# Altium schematic sheet 02 POE Main Power.SchDoc("02 POE Main Power")
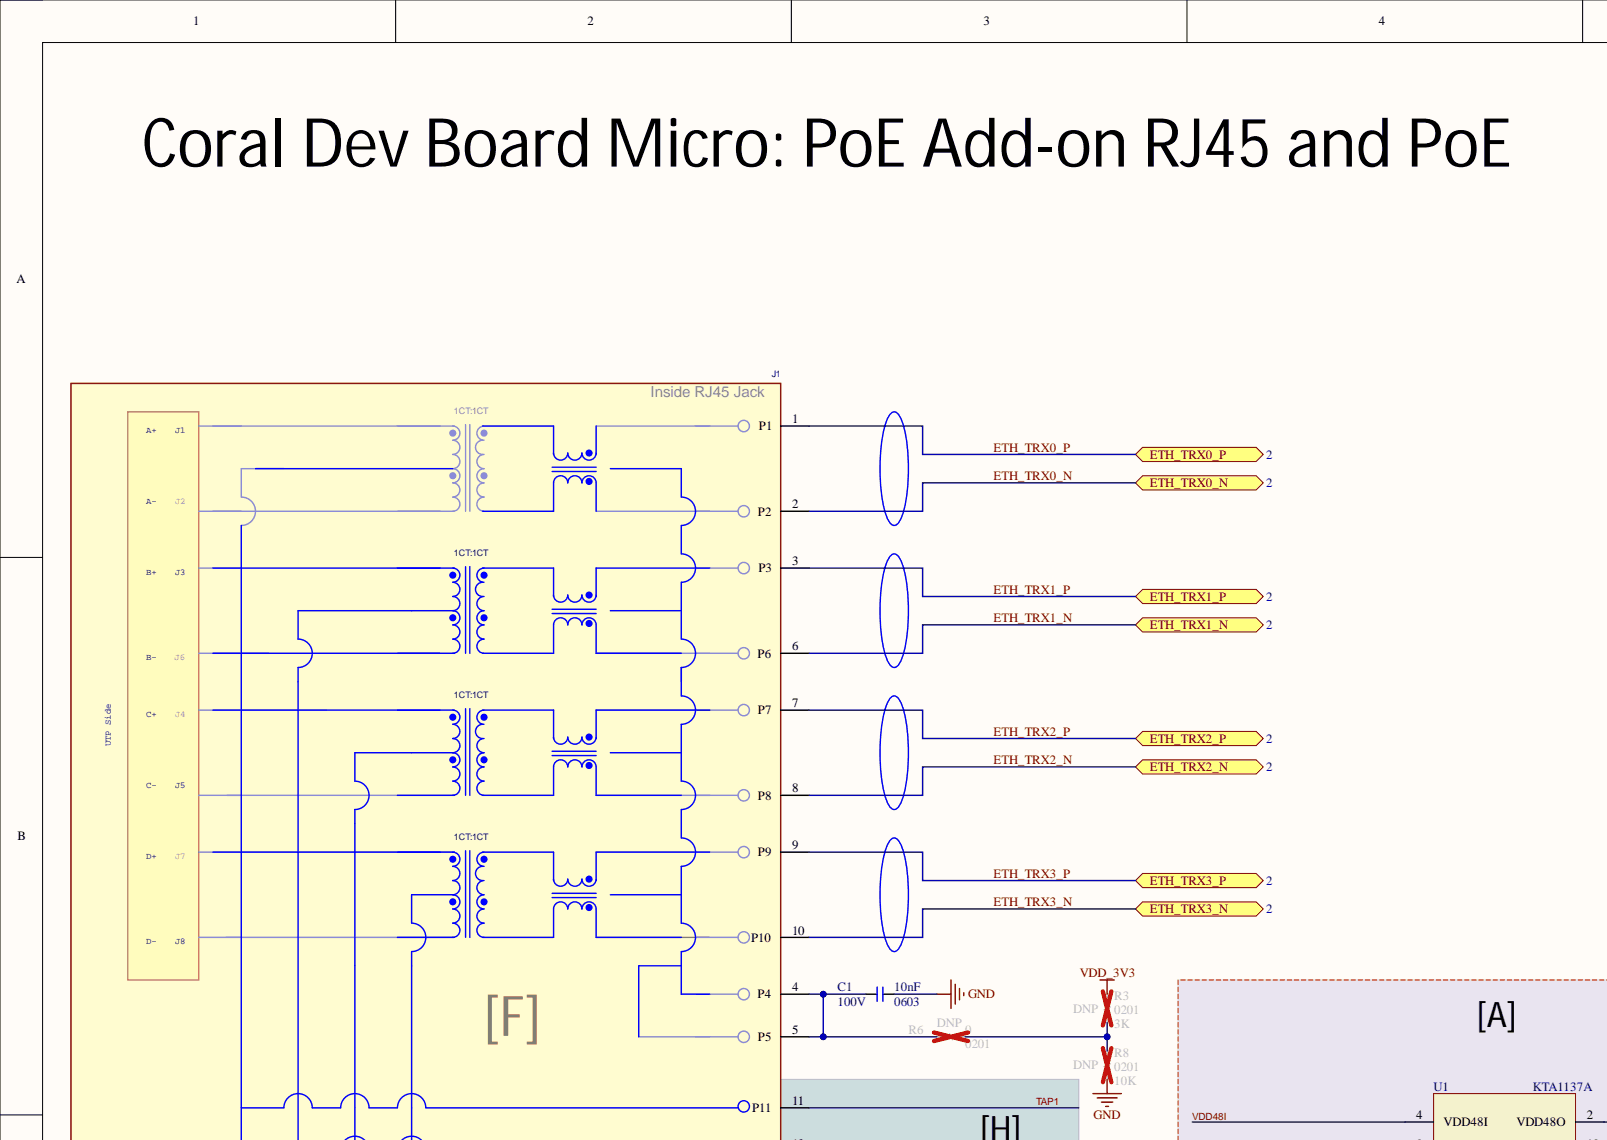
[diagram p0: 02 POE Main Power.SchDoc, top left]
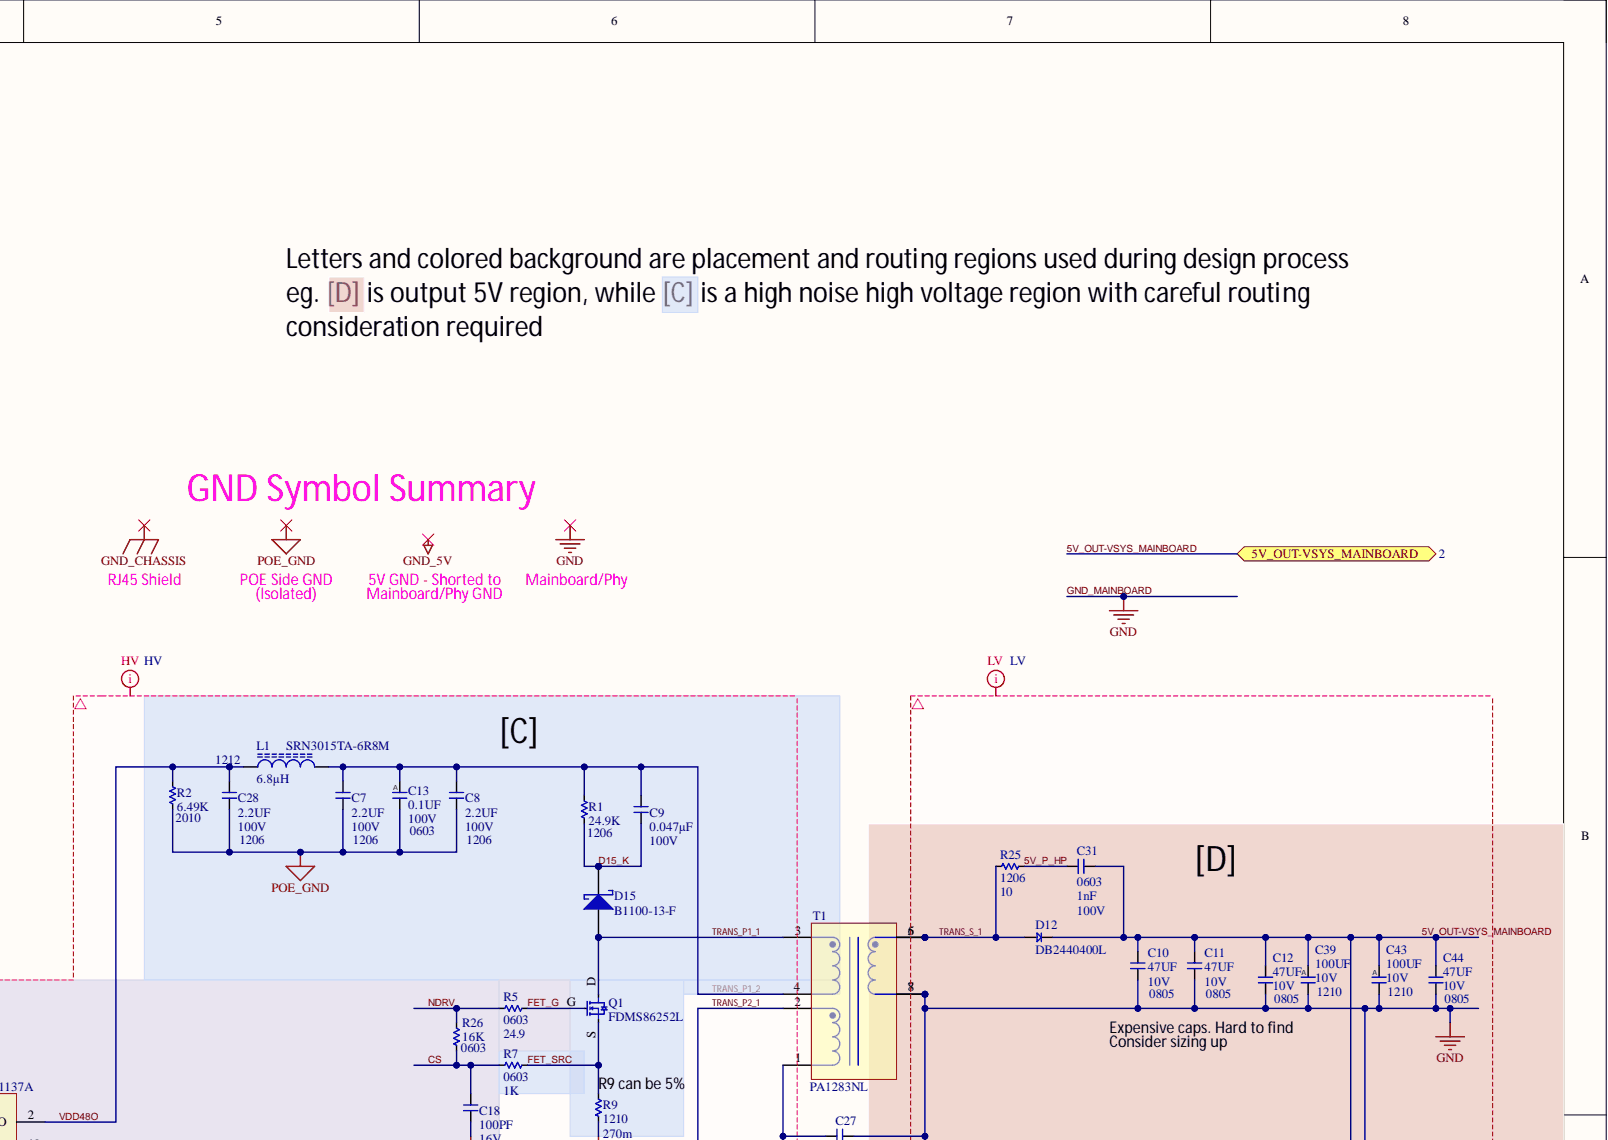
[diagram p1: 02 POE Main Power.SchDoc, top right]
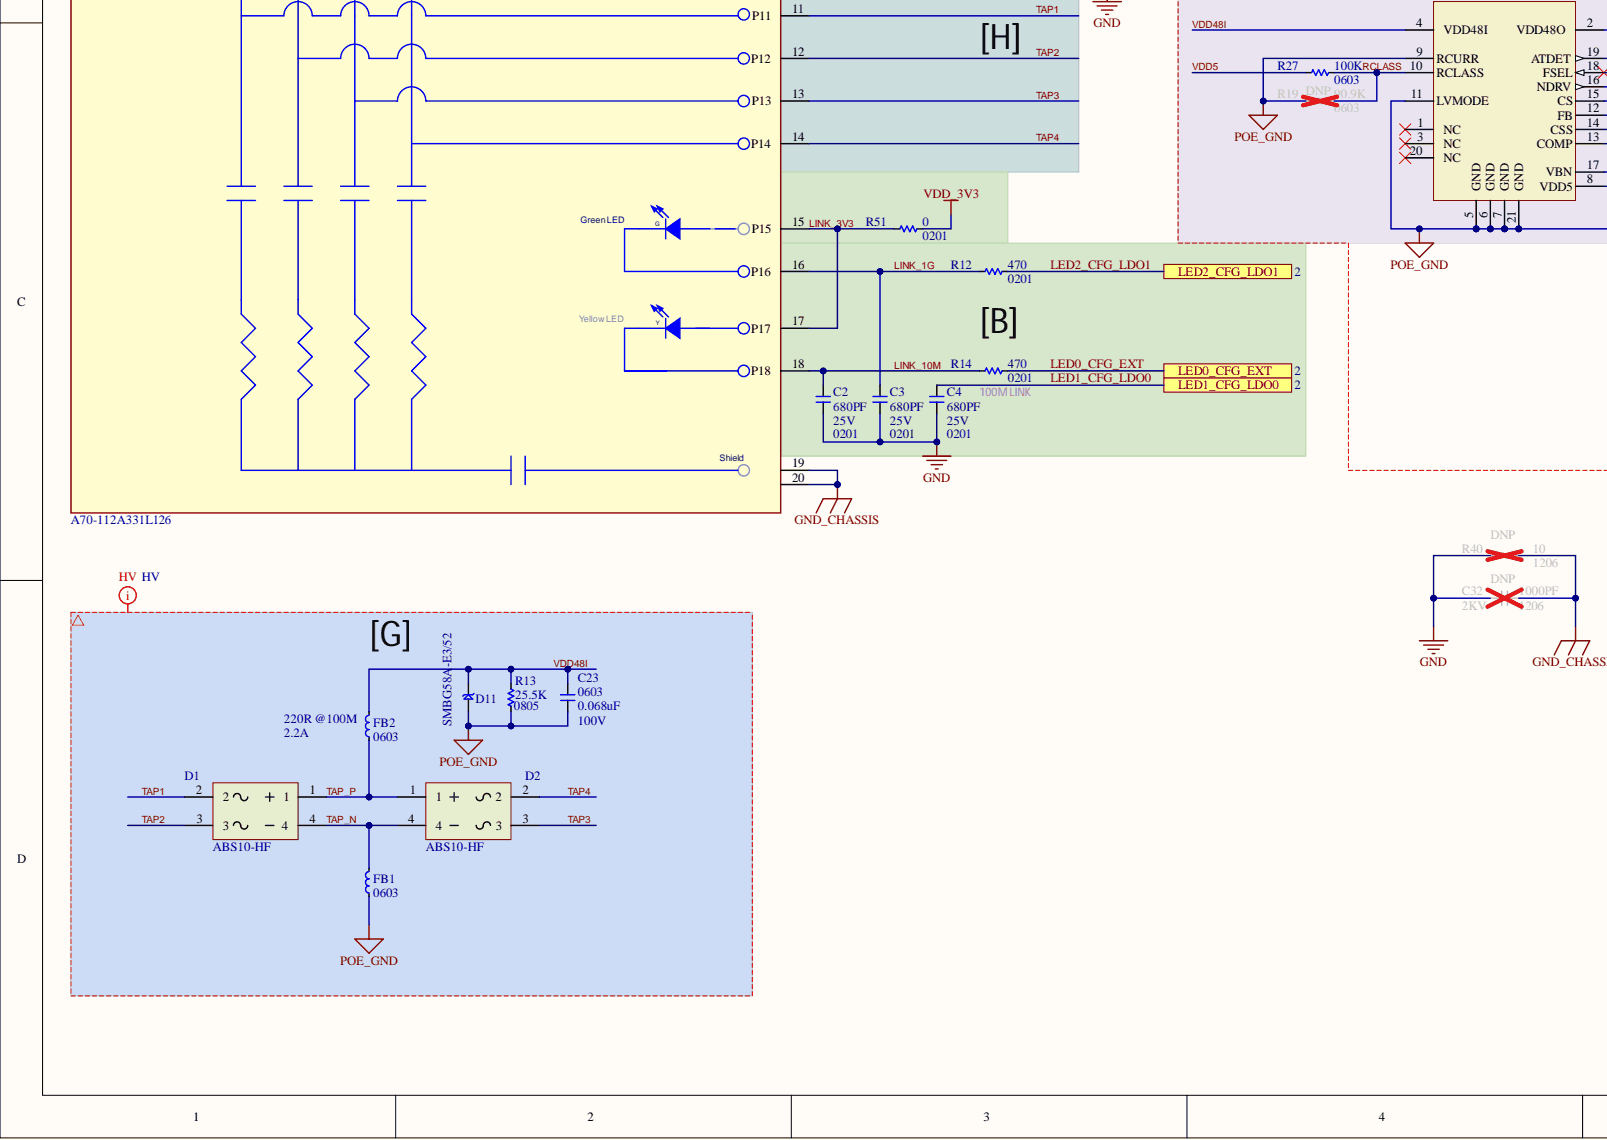
[diagram p2: 02 POE Main Power.SchDoc, bottom left]
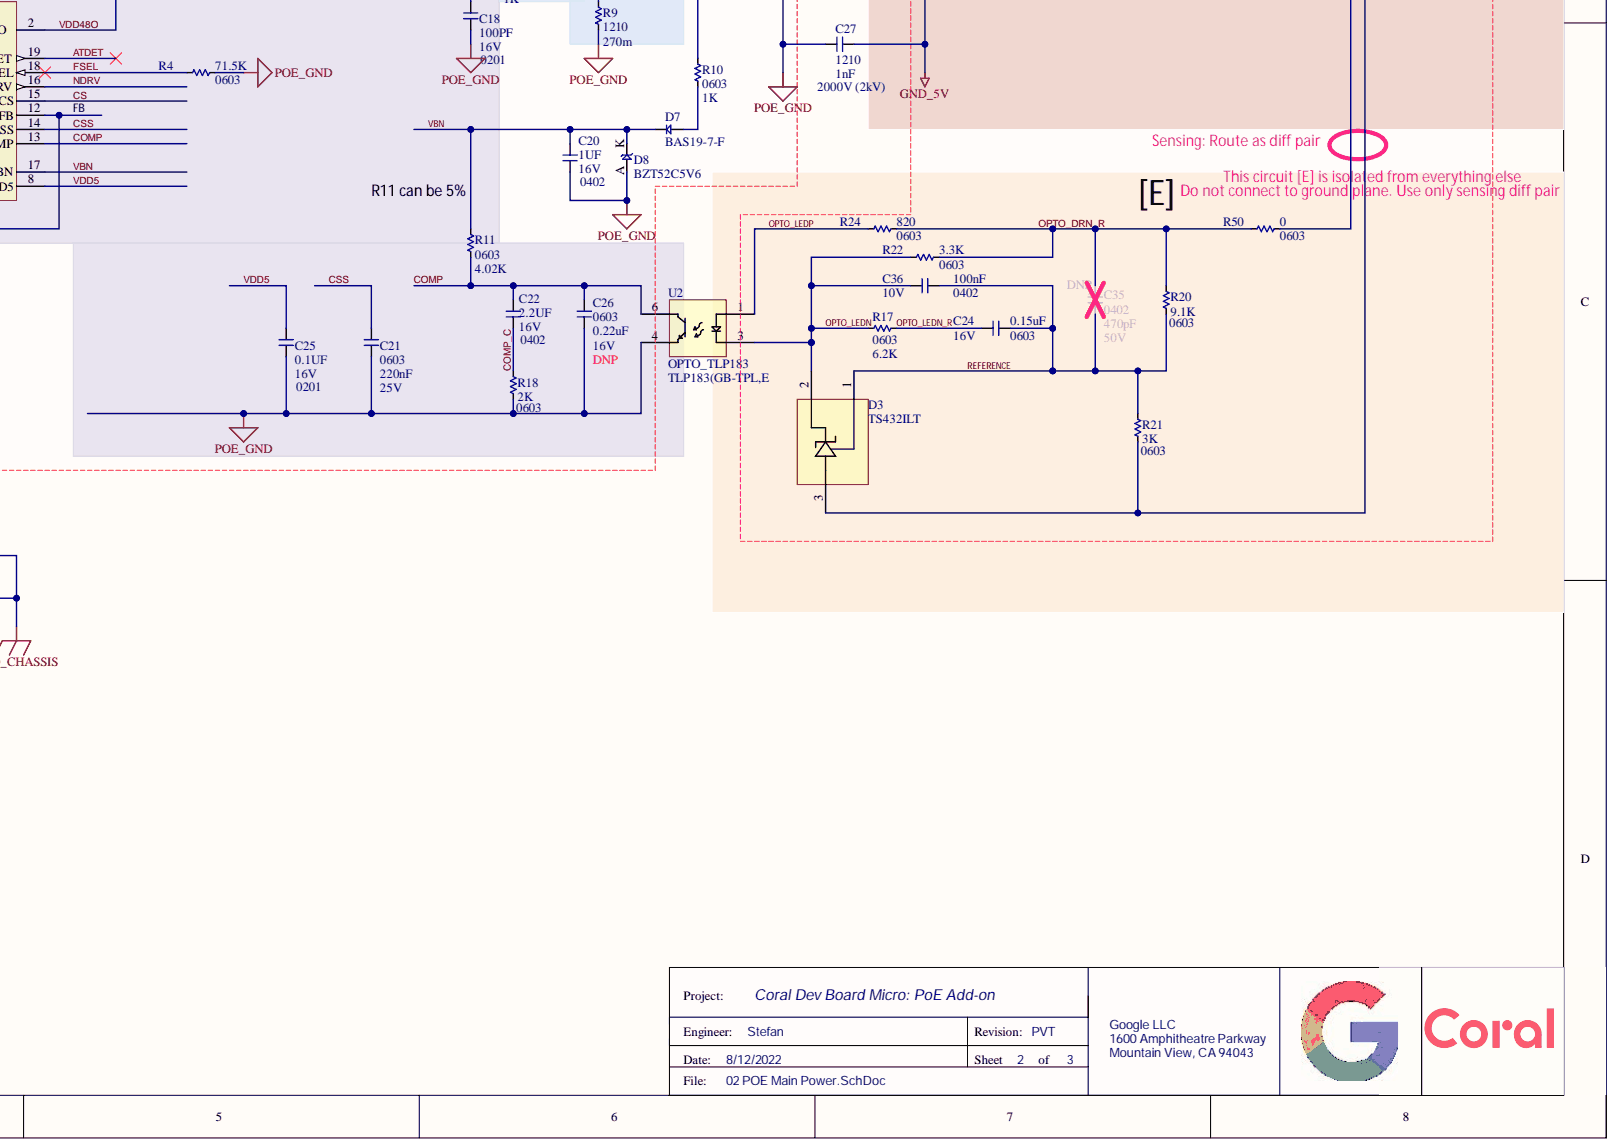
[diagram p3: 02 POE Main Power.SchDoc, bottom right]

## Parts
C1  value 10nF  Package: 0603  Voltage: 100V  [C0603]  (hidden comment: __Template_Cap)
C2  value 680PF  Package: 0201  Voltage: 25V  [C0201Y]  (hidden comment: __Template_Cap)
C3  value 680PF  Package: 0201  Voltage: 25V  [C0201Y]  (hidden comment: __Template_Cap)
C4  value 680PF  Package: 0201  Voltage: 25V  [C0201Y]  (hidden comment: __Template_Cap)
C7  value 2.2UF  Package: 1206  Voltage: 100V  [C1206]  (hidden comment: __Template_Cap)
C8  value 2.2UF  Package: 1206  Voltage: 100V  [C1206]  (hidden comment: __Template_Cap)
C9  value 0.047µF  Voltage: 100V  [C0805Y_H1.4MM]  (hidden comment: __Template_Cap)
C10  value 47UF  Package: 0805  Voltage: 10V  [C0805]  (hidden comment: __Template_Cap)
C11  value 47UF  Package: 0805  Voltage: 10V  [C0805]  (hidden comment: __Template_Cap)
C12  value 47UF  Package: 0805  Voltage: 10V  [C0805]  (hidden comment: __Template_Cap)
C13  value 0.1UF  Package: 0603  Voltage: 100V  [C0603]  (hidden comment: __Template_Cap_Auto)
C18  value 100PF  Package: 0201  Voltage: 16V  [C0201Y]  (hidden comment: __Template_Cap)
C20  value 1UF  Package: 0402  Voltage: 16V  [C0402]  (hidden comment: __Template_Cap)
C21  value 220nF  Package: 0603  Voltage: 25V  [C0603]  (hidden comment: __Template_Cap)
C22  value 2.2UF  Package: 0402  Voltage: 16V  [C0402]  (hidden comment: __Template_Cap)
C23  value 0.068uF  Package: 0603  Voltage: 100V  [C0603_H0.9MM]  (hidden comment: __Template_Cap)
C24  value 0.15uF  Package: 0603  Voltage: 16V  [C0603_H0.87MM]  (hidden comment: __Template_Cap)
C25  value 0.1UF  Package: 0201  Voltage: 16V  [C0201]  (hidden comment: __Template_Cap)
C26  value 0.22uF  Package: 0603  Voltage: 16V  [C0603_H0.9MM_V1]  (hidden comment: __Template_Cap)
C27  value 1nF  Package: 1210  Voltage: 2000V (2kV)  [C1210_H2.8MM_V1]  (hidden comment: __Template_Cap)
C28  value 2.2UF  Package: 1206  Voltage: 100V  [C1206]  (hidden comment: __Template_Cap)
C31  value 1nF  Package: 0603  [C0603]  (hidden comment: __Template_Cap)
C32  value 1000PF  Package: 1206  Voltage: 2KV  [C1206]  (hidden comment: __Template_Cap)
C35  value 470pF  Package: 0402  Voltage: 50V  [C0402]  (hidden comment: __Template_Cap_Auto)
C36  value 100nF  Package: 0402  Voltage: 10V  [C0402]  (hidden comment: __Template_Cap)
C39  value 100UF  Package: 1210  Voltage: 10V  [C1210]  (hidden comment: __Template_Cap_Auto)
C43  value 100UF  Package: 1210  Voltage: 10V  [C1210]  (hidden comment: __Template_Cap_Auto)
C44  value 47UF  Package: 0805  Voltage: 10V  [C0805]  (hidden comment: __Template_Cap)
D1  value ABS10-HF  MFG P/N: ABS10-HF  [COMCHIPS_ABS10-HF]  (hidden comment: RECTIFIER_COMCHIPS_ABS10-HF)
D2  value ABS10-HF  MFG P/N: ABS10-HF  [COMCHIPS_ABS10-HF]  (hidden comment: RECTIFIER_COMCHIPS_ABS10-HF)
D3  MFG P/N: TS432ILT  [SOT95P237X112-3]  (hidden comment: SHUNT_TS432ILT)
D7  value BAS19-7-F  MFG P/N: BAS19-7-F  [SOT200X240X110-3_AK_CUS]  (hidden comment: __Template_Diode_STD_AK)
D8  value BZT52C5V6  MFG P/N: BZT52C5V6  [SOD123F_H1.30MM]  (hidden comment: __Template_Diode_Zener_A/K_V1)
D11  value SMBG58A-E3/52  MFG P/N: SMBG58A-E3/52  [DO-215AA_H2.61MM]  (hidden comment: __Template_Diode_TVS_A/K)
D12  value DB2440400L  MFG P/N: DB2440400L  [SOD128_AK_V2]  (hidden comment: __Template_Diode_STD)
D15  value B1100-13-F  MFG P/N: B1100-13-F  [SMA_A_K_H2.4MM_V1]  (hidden comment: __Template_DIODE_SCHOTTKY)
FB1  Package: 0603  [L0603_H0.95MM]  (hidden comment: FB_MPZ1608S221A)
FB2  Package: 0603  [L0603_H0.95MM]  (hidden comment: FB_MPZ1608S221A)
J1  MFG P/N: A70-112A331L126  [EDAC_A70-112A331L126]
L1  value 6.8µH  MFG P/N: SRN3015TA-6R8M  Package: 1212  [INDP300X300X150]  (hidden comment: _Template_Inductor_Core_V1)
Q1  MFG P/N: FDMS86252L  [NFET_FDMS86252L]  (hidden comment: __Template_NFET_GDS)
R1  value 24.9K  Package: 1206  [R1206]
R2  value 6.49K  Package: 2010  [R2010]
R3  value 3K  Package: 0201  [R0201]
R4  value 71.5K  Package: 0603  [R0603]
R5  value 24.9  Package: 0603  [R0603]
R6  value 0  Package: 0201  [R0201]
R7  value 1K  Package: 0603  [R0603]
R8  value 10K  Package: 0201  [R0201]
R9  value 270m  Package: 1210  [R1210]
R10  value 1K  Package: 0603  [R0603]
R11  value 4.02K  Package: 0603  [R0603]
R12  value 470  Package: 0201  [R0201]
R13  value 25.5K  Package: 0805  [R0805]
R14  value 470  Package: 0201  [R0201]
R17  value 6.2K  Package: 0603  [R0603]
R18  value 2K  Package: 0603  [R0603]
R19  value 90.9K  Package: 0603  [R0603]
R20  value 9.1K  Package: 0603  [R0603]
R21  value 3K  Package: 0603  [R0603]
R22  value 3.3K  Package: 0603  [R0603]
R24  value 820  Package: 0603  [R0603]
R25  value 10  Package: 1206  [R1206]
R26  value 16K  Package: 0603  [R0603]
R27  value 100K  Package: 0603  [R0603]
R40  value 10  Package: 1206  [R1206]
R50  value 0  Package: 0603  [R0603]
R51  value 0  Package: 0201  [R0201]
T1  MFG P/N: PA1283NL  [XFMR_PA1283NL]
U1  MFG P/N: KTA1137A  [QFN65P500X500X100-20-TP]  (hidden comment: DC-DC-CONTROLLER_KTA1137A)
U2  OPTO_TLP183  MFG P/N: TLP183(GB-TPL,E  [6SO-4]

## Ports
5V_OUT-VSYS_MAINBOARD, ETH_TRX0_N, ETH_TRX0_P, ETH_TRX1_N, ETH_TRX1_P, ETH_TRX2_N, ETH_TRX2_P, ETH_TRX3_N, ETH_TRX3_P, LED0_CFG_EXT, LED1_CFG_LDO0, LED2_CFG_LDO1

## Nets
GND_CHASSIS: C32.2 J1.19 J1.20 R40.2
POE_GND: C7.2 C8.2 C13.2 C18.2 C20.2 C21.2 C23.2 C25.2 C26.2 C27.2 C28.2 D8.A D11.A FB1.1 R2.1 R4.2 R9.1 R13.1 R18.1 R19.2 T1.1 U1.5(GND) U1.6(GND) U1.7(GND) U1.9(RCURR) U1.11(LVMODE) U1.12(FB) U1.21(GND) U2.4
VDD_3V3: R3.2 R51.2
5V_OUT-VSYS_MAINBOARD: C10.1 C11.1 C12.1 C31.2 C39.1 C43.1 C44.1 D12.K R50.2 ; port 5V_OUT-VSYS_MAINBOARD
5V_P_HP: C31.1 R25.1
ATDET: U1.19(ATDET)
COMP: C22.1 C26.1 R11.1 U1.13(COMP) U2.6
COMP_C: C22.2 R18.2
CS: C18.1 R7.2 R26.1 U1.15(CS)
CSS: C21.1 U1.14(CSS)
D15_K: C9.2 D15.K R1.1
ETH_TRX0_N: J1.2(P2) ; port ETH_TRX0_N
ETH_TRX0_P: J1.1(P1) ; port ETH_TRX0_P
ETH_TRX1_N: J1.6(P6) ; port ETH_TRX1_N
ETH_TRX1_P: J1.3(P3) ; port ETH_TRX1_P
ETH_TRX2_N: J1.8(P8) ; port ETH_TRX2_N
ETH_TRX2_P: J1.7(P7) ; port ETH_TRX2_P
ETH_TRX3_N: J1.10(P10) ; port ETH_TRX3_N
ETH_TRX3_P: J1.9(P9) ; port ETH_TRX3_P
FET_G: Q1.G R5.1
FET_SRC: Q1.S R7.1 R9.2
FSEL: R4.1 U1.18(FSEL)
GND_MAINBOARD: C1.2 C2.2 C3.2 C4.2 C10.2 C11.2 C12.2 C27.1 C32.1 C39.2 C43.2 C44.2 D3.3 R8.1 R21.1 R40.1 T1.7 T1.8
LED0_CFG_EXT: R14.2 ; port LED0_CFG_EXT
LED1_CFG_LDO0: C4.1 ; port LED1_CFG_LDO0
LED2_CFG_LDO1: R12.2 ; port LED2_CFG_LDO1
LINK_1G: C3.1 J1.16(P16) R12.1
LINK_3V3: J1.15(P15) J1.17(P17) R51.1
LINK_10M: C2.1 J1.18(P18) R14.1
NDRV: R5.2 R26.2 U1.16(NDRV)
OPTO_DRN_R: C35.1 R20.2 R22.1 R24.2 R50.1
OPTO_LEDN: C36.1 D3.2 R17.2 R22.2 U2.3
OPTO_LEDN_R: C24.1 R17.1
OPTO_LEDP: R24.1 U2.1
RCLASS: R19.1 R27.1 U1.10(RCLASS)
REFERENCE: C24.2 C35.2 C36.2 D3.1 R20.1 R21.2
TAP1: D1.2 J1.11(P11)
TAP2: D1.3 J1.12(P12)
TAP3: D2.3 J1.13(P13)
TAP4: D2.2 J1.14(P14)
TAP_N: D1.4 D2.4 FB1.2
TAP_P: D1.1 D2.1 FB2.1
TRANS_P1_1: D15.A Q1.D T1.3
TRANS_P1_2: C7.1 C8.1 C9.1 C13.1 L1.2 R1.2 T1.4
TRANS_P2_1: R10.1 T1.2
TRANS_S_1: D12.A R25.2 T1.5 T1.6
VBN: C20.1 D7.K D8.K R11.2 U1.17(VBN)
VDD5: C25.1 R27.2 U1.8(VDD5)
VDD48I: C23.1 D11.K FB2.2 R13.2 U1.4(VDD48I)
VDD48O: C28.1 L1.1 R2.2 U1.2(VDD48O)
NetC1_1: C1.1 J1.4(P4) J1.5(P5) R6.1
NetD7_A: D7.A R10.2
NetR3_1: R3.1 R6.2 R8.2

Not connected: U1 1(NC) 3(NC) 20(NC)

## Library data of the parts
C1 = 865: CAP, CER, X7R, 0.01uF, 100V, 10%, 0603, 0.9mm T; COMMON: 150-00342-00; Database Table Name: Capacitors; Gecko: 150-04658-00; MFG: TDK; MFG P/N: C1608X7R2A103K080AA; XPN: XS-10000719; Component Kind: Standard; ComponentLink1Description: Datasheet; Dielectric: X7R; Height: 0.9mm T; ID: 865; Library Name: AltiumReleaseLibDB.DBLib; Status: Released; Tolerance: 10%
C2-C4 = 118: CAP, CER, X7R, 680pF, 25V, 10%, 0201, 0.33mm T; COMMON: 150-00850-00; Database Table Name: Capacitors; MFG: MURATA; MFG P/N: GRM033R71E681KA01D; Component Kind: Standard; ComponentLink1Description: Datasheet; Dielectric: X7R; Height: 0.33mm T; ID: 118; Library Name: AltiumReleaseLibDB.DBLib; Status: Released; Tolerance: 10%; XPN: 150-00850-00
C7 C8 C28 = 941: CAP, CER, X7S, 2.2uF, 100V, 10%, 1206, 1.8mm T; COMMON: 150-00310-00; Database Table Name: Capacitors; Gecko: 150-04626-00; KOI: 150-04323-00; MFG: TDK; MFG P/N: C3216X7S2A225K160AB; Component Kind: Standard; ComponentLink1Description: Datasheet; Dielectric: X7S; Height: 1.8mm T; ID: 941; Library Name: AltiumReleaseLibDB.DBLib; Status: Released; Tolerance: 10%; XPN: 150-00310-00
C9 = 1858: CAP, CER, X7R, 47nF, 100V, 10%, 0805; Database Table Name: Capacitors; MFG: KEMET; MFG P/N: C0805C473K1RECAUTO; Component Kind: Standard; ComponentLink1Description: Datasheet; ComponentLink1URL: https://content.kemet.com/datasheets/KEM_C1090_X7R_ESD.pdf; Dielectric: X7R; Height: 1.4mm; HelpURL: https://www.digikey.com/en/products/detail/kemet/C0805C473K1RECAUTO/8646736; ID: 1858; Library Name: AltiumReleaseLibDB.DBLib; Package: 0805; Status: Released; Supplier 1: Digi-Key; Supplier Part Number 1: 399-C0805C473K1RECAUTOTR-ND; Tolerance: 10%
C10-C12 C44 = 1028: CAP, CER, X5R, 47uF, 20%, 10V, 0805; COMMON: 150-00382-00; Database Table Name: Capacitors; MFG: TDK; MFG P/N: C2012X5R1A476M125AC; PROXY: 150-03505-00; Component Kind: Standard; ComponentLink1Description: Datasheet; Dielectric: X5R; Height: 1.45mm T; ID: 1028; Library Name: AltiumReleaseLibDB.DBLib; Status: Released; Tolerance: 20%; XPN: 150-00382-00
C13 = 1039: CAP, CER, X7S, 0.1uF, 100V, 10%, 0603, AUTOGRADE; COMMON: 150-00222-00; Database Table Name: Capacitors; Dielectric: X7R; EES: 150-04577-00; Gecko: 150-04433-00; KOI: 150-04632-00; MFG: TDK; MFG P/N: CGA3E3X7S2A104K080AB; PROXY: 150-03755-00; Component Kind: Standard; ComponentLink1Description: Datasheet; Height: 0.9mm T; ID: 1039; Library Name: AltiumReleaseLibDB.DBLib; Status: Released; Tolerance: 10%; XPN: 150-00222-00
C18 = 139: CAP, CER, X7R, 100pF, 10%, 16V, 0201; COMMON: 150-00316-00; Database Table Name: Capacitors; EES: 150-04571-00; MFG: MURATA; MFG P/N: GRM033R71C101KA01D; PROXY: Use ID #663; Component Kind: Standard; ComponentLink1Description: Datasheet; Dielectric: X7R; Height: 0.33mm T; ID: 139; Library Name: AltiumReleaseLibDB.DBLib; Status: Released; Tolerance: 10%; XPN: 150-00316-00
C20 = 104: CAP, CER, X5R, 1uF, 20%, 16V, 0402; COMMON: 150-01208-00; Database Table Name: Capacitors; MFG: MURATA; MFG P/N: GRM155R61C105MA12D; PROXY: Use ID #1729; Component Kind: Standard; ComponentLink1Description: Datasheet; Dielectric: X5R; Height: 0.56mm T; ID: 104; Library Name: AltiumReleaseLibDB.DBLib; Status: Released; Tolerance: 20%; XPN: 150-01208-00
C21 = 884: CAP, CER, X7R, 0.22uF, 10%, 25V, 0603; COMMON: 150-01181-00; Database Table Name: Capacitors; MFG: MURATA; MFG P/N: GRM188R71E224KA88D; PROXY: Alt ID #1175; Component Kind: Standard; ComponentLink1Description: Datasheet; Dielectric: X7R; Height: 0.9mm T; ID: 884; Library Name: AltiumReleaseLibDB.DBLib; Status: NRND; Tolerance: 10%; XPN: 150-01181-00
C22 = 335: CAP, CER, X5R, 2.2uF, 10%, 16V, 0402; COMMON: 150-00040-00; Database Table Name: Capacitors; MFG: SAMSUNG; MFG P/N: CL05A225KO5NQNC; PROXY: Use ID #1110; Component Kind: Standard; ComponentLink1Description: Datasheet; Dielectric: X5R; Height: 0.56mm T; ID: 335; Library Name: AltiumReleaseLibDB.DBLib; Status: Released; Tolerance: 10%; XPN: 150-00040-00
C23 = 1908: CAP, CER, X8R, 0.068uF, 100V, 10%, 0603, AUTOGRADE; Database Table Name: Capacitors; MFG: Murata Electronics; MFG P/N: GCJ188R92A683KA01D; Component Kind: Standard; ComponentLink1Description: Datasheet; ComponentLink1URL: https://search.murata.co.jp/Ceramy/image/img/A01X/G101/ENG/GCJ188R92A683KA01-01.pdf; Dielectric: X8R; Height: 0.9mm; HelpURL: https://www.digikey.com/en/products/detail/murata-electronics/GCJ188R92A683KA01D/11618570?s=N4IgTCBcDaIOIGEBSBGAHGgSgTjAQQDY0BmAaTwAYUAREAXQF8g; ID: 1908; Library Name: AltiumReleaseLibDB.DBLib; Status: Released; Supplier 1: Digi-Key; Supplier Part Number 1: 490-GCJ188R92A683KA01DTR-ND; Tolerance: 10%
C24 = 1909: CAP, CER, X7R, 0.15uF, 16V, 10%, 0603; Database Table Name: Capacitors; MFG: KEMET; MFG P/N: C0603C154K4RAC7867; Component Kind: Standard; ComponentLink1Description: Datasheet; ComponentLink1URL: https://content.kemet.com/datasheets/KEM_C1002_X7R_SMD.pdf; Dielectric: X7R; Height: 0.87mm; HelpURL: https://www.digikey.com/en/products/detail/kemet/C0603C154K4RAC7867/2269185?s=N4IgTCBcDaIMIAYBsCDMcCMBWALAaRwCUBBOAdgA4kyQBdAXyA; ID: 1909; Library Name: AltiumReleaseLibDB.DBLib; Status: Released; Supplier 1: Digi-Key; Supplier Part Number 1: 399-C0603C154K4RAC7867TR-ND; Tolerance: 10%
C25 = 1355: CAP, CER, X5R, 0.1uF, 16V, 10%, 0201, 0.33mm T; COMMON: 150-00149-00; Database Table Name: Capacitors; MFG: TDK; MFG P/N: C0603X5R1C104K030BC; Component Kind: Standard; ComponentLink1Description: Datasheet; Dielectric: X5R; Height: 0.33mm T; ID: 1355; Library Name: AltiumReleaseLibDB.DBLib; Status: NRND; Tolerance: 10%; XPN: 150-00149-00
C26 = 1910: CAP, CER, Y5V, 0.22uF, 16V, -20 TO 80%, 0603; Database Table Name: Capacitors; Dielectric: Y5V (F); MFG: KEMET; MFG P/N: C0603C224Z4VAC7867; Tolerance: -20%, +80%; Component Kind: Standard; ComponentLink1Description: Datasheet; ComponentLink1URL: https://connect.kemet.com:7667/gateway/IntelliData-ComponentDocumentation/1.0/download/datasheet/C0603C224Z4VACTU; DNP: DNP; Height: 0.90mm; HelpURL: https://www.digikey.com/en/products/detail/kemet/C0603C224Z4VAC7867/411101?s=N4IgTCBcDaIMIAYBsCDMcxgCwC0sDUBBOAdgA4kSQBdAXyA; ID: 1910; Library Name: AltiumReleaseLibDB.DBLib; Status: Released; Supplier 1: Digi-Key; Supplier Part Number 1: 399-C0603C224Z4VAC7867TR-ND
C27 = 1865: CAP, CER, X7R, 1nF, 2KV, 10%, 1210; Database Table Name: Capacitors; MFG: AVX Corporation; MFG P/N: 1210GC102KAT1A; Component Kind: Standard; ComponentLink1Description: Datasheet; ComponentLink1URL: https://datasheets.avx.com/AVX-HV_MLCC.pdf; Dielectric: X7R; Height: 2.8mm; HelpURL: https://www.digikey.com/en/products/detail/avx-corporation/1210GC102KAT1A/608972?s=N4IgTCBcDaIIxjgBgOIGFlgNIEEAqcOIAugL5A; ID: 1865; Library Name: AltiumReleaseLibDB.DBLib; Status: Released; Supplier 1: Digi-Key; Supplier Part Number 1: 478-2960-2-ND; Tolerance: 10%
C31 = 148: CAP, CER, X7R, 1000pF, 100V, 20%, 0603, 0.9mm T; COMMON: 150-00799-00; Database Table Name: Capacitors; MFG: YAGEO; MFG P/N: CX0603MRX7R0BB102; Component Kind: Standard; ComponentLink1Description: Datasheet; Dielectric: X7R; Height: 0.9mm T; ID: 148; Library Name: AltiumReleaseLibDB.DBLib; Status: Released; Tolerance: 20%; Voltage: 100V; XPN: 150-00799-00
C32 = 1550: CAP, CER, X7R, 1000pF, 2KV, 10%, 1206; COMMON: 150-00167-00; Database Table Name: Capacitors; EES: 150-04576-00; KOI: 150-04127-00; MFG: YAGEO; MFG P/N: CC1206KKX7RDBB102; PROXY: Use ID #1711; Component Kind: Standard; Dielectric: X7R; DNP: DNP; Height: 1.45mm; ID: 1550; Library Name: AltiumReleaseLibDB.DBLib; Status: Released; Tolerance: 10%; XPN: 150-00167-00
C35 = 842: CAP, CER, X7R, 470PF, 50V, 10%, 0402, AUTOGRADE; COMMON: 150-00572-00; Database Table Name: Capacitors; Gecko: 150-04393-00; MFG: TDK; MFG P/N: CGA2B2X7R1H471K050BA; PROXY: 150-03617-00; XPN: XS-10000790; Component Kind: Standard; ComponentLink1Description: Datasheet; Dielectric: X7R; DNP: DNP; Height: 0.56mm T; ID: 842; Library Name: AltiumReleaseLibDB.DBLib; Status: Released; Tolerance: 10%
C36 = 327: CAP, CER, X5R, 0.1uF, 10%, 10V, 0402; COMMON: 150-00162-00; Database Table Name: Capacitors; MFG: TDK; MFG P/N: C1005X5R1A104K050BA; PROXY: Alt ID #1245; Component Kind: Standard; ComponentLink1Description: Datasheet; Dielectric: X5R; Height: 0.56mm T; ID: 327; Library Name: AltiumReleaseLibDB.DBLib; Status: NRND; Tolerance: 10%; XPN: 150-00162-00
C39 C43 = 36: Capacitor, 100uF, 1210, X5R, 10V, ±20%; COMMON: 150-01139-00; Database Table Name: Capacitors; MFG: Taiyo Yuden; MFG P/N: LMK325ABJ107MMHT; XPN: XS-10001082; Component Kind: Standard; ComponentLink1Description: Datasheet; Dielectric: X5R; Height: 2.70mm; ID: 36; Library Name: AltiumReleaseLibDB.DBLib; Status: Released; Supplier 1: Digi-Key; Supplier Part Number 1: 587-3432-1-ND; Tolerance: 20%
D1 D2 = 363: DIODE, RECTIFIER, 1KV VRRM, 1A IO, 30A SURGE, SMD-4; Current - Reverse Leakage @ VR: 5 µA @ 1000 V; Database Table Name: Diodes; Diode Type: Bridge Rectifier; MFG: Comchip Technology; Voltage – Forward (VF) (MAX) @ IF: 1.1 V @ 1 A; Category: DIODE; Component Kind: Standard; ComponentLink1Description: Datasheet; ComponentLink1URL: https://drive.google.com/file/d/1cMImUZzECR5fc_QIqpqw9BASnm05J-9G/view; Current - Average Rectified (IO): 1A; Height: 1.5mm; HelpURL: https://www.digikey.com/en/products/detail/comchip-technology/ABS10-HF/3308099?s=N4IgTCBcDaIIICEDKBGADAWgBIDEQF0BfIA; ID: 363; Library Name: AltiumReleaseLibDB.DBLib; Mounting Type: SMD; Operating Temperature – Junction: -55°C ~ 150°C (TJ); Package: 4-SMD; RoHS Compliant: Yes; Status: Released; Supplier 1: Digi-Key; Supplier Part Number 1: 641-2018-2-ND
D3 = 860: IC, VOLTAGE REFERENCE, TS432, SHUNT, ADJ, 1.24V VIN, 1% TOL, 10V VOUT, 12mA, SOT-23-3; Database Table Name: IC - PMIC; MFG: STMicroelectronics; Category: Integrated Circuits (ICs); Component Kind: Standard; ComponentLink1Description: Datasheet; ComponentLink1URL: https://www.st.com/content/ccc/resource/technical/document/datasheet/eb/6c/8a/b0/d5/2f/4b/6b/CD00003202.pdf/files/CD00003202.pdf/jcr:content/translations/en.CD00003202.pdf; Height: 1.12mm; HelpURL: https://www.digikey.com/en/products/detail/stmicroelectronics/TS432ILT/1040226?s=N4IgTCBcDaICoGUAsBmMBJAMnEBdAvkA; ID: 860; Library Name: AltiumReleaseLibDB.DBLib; Mounting Type: SMD; Package: SOT-23-3; RoHS Compliant: Yes; Status: Released; Supplier 1: Digi-Key; Supplier Part Number 1: 497-8108-2-ND
D7 = 339: DIODE, STANDARD, 100V VRRM, 200mA IO, 0.5A SURGE, SOT-23-3; Current - Reverse Leakage @ VR: 100nA @ 100V; Database Table Name: Diodes; MFG: Diodes Incorporated; Voltage – Forward (VF) (MAX) @ IF: 1.25V @ 200mA; Category: Diode; Component Kind: Standard; ComponentLink1Description: Datasheet; ComponentLink1URL: https://www.diodes.com/assets/Datasheets/Ds12004.pdf; Current - Average Rectified (IO): 200mA; Diode Type: Standard; Height: 1.1mm; HelpURL: https://www.digikey.com/en/products/detail/diodes-incorporated/BAS19-7-F/768775?s=N4IgTCBcDaIEIEEDKBGAnAWgOwYGIgF0BfIA; ID: 339; Library Name: AltiumReleaseLibDB.DBLib; Mounting Type: SMD; Operating Temperature – Junction: -65°C ~ 150°C; Package: SOT-23-3; RoHS Compliant: Yes; Status: Released; Supplier 1: Digi-Key; Supplier Part Number 1: BAS19-FDITR-ND; Voltage - DC Reverse (VR) (MAX): 100V
D8 = 335: DIODE, ZENER, 5.6V, 5%, 500mW, 80 OHM, SOD-123F; Database Table Name: Diodes; MFG: Diodes Incorporated; Category: Diode; Component Kind: Standard; ComponentLink1Description: Datasheet; ComponentLink1URL: https://www.mouser.com/datasheet/2/115/ds18004-1142534.pdf; Diode Type: Zener; Height: 1.30mm; HelpURL: https://www.mouser.com/ProductDetail/Diodes-Incorporated/BZT52C5V6/?qs=2YfbMANv3814Zhb4%2FgiiCA%3D%3D; ID: 335; Library Name: AltiumReleaseLibDB.DBLib; Mounting Type: SMD; Operating Temperature – Junction: -65°C ~ 150°C (TJ); Package: SOD-123-2; RoHS Compliant: Yes; Status: Released; Supplier 1: Mouser
D11 = 332: DIODE, TVS, UNIDIR, 58VRWM, 64.4VBR, 93.6VCL, DO-215AA; Database Table Name: Diodes; MFG: Vishay Semiconductor Diodes Division; Voltage - Clamping (Max) @ Ipp: 93.6V; Category: Diode; Component Kind: Standard; ComponentLink1Description: Datasheet; ComponentLink1URL: https://www.vishay.com/docs/88456/smbg.pdf; Diode Type: TVS; Height: 2.61mm; HelpURL: https://www.digikey.in/products/en?keywords=SMBG58A-E3%2F52; ID: 332; Library Name: AltiumReleaseLibDB.DBLib; Mounting Type: SMD; Operating Temperature – Junction: -55°C ~ 150°C (TJ); Package: DO-215AA (SMBG); RoHS Compliant: Yes; Status: Released; Supplier 1: Digi-Key; Supplier Part Number 1: SMBG58A-E3/52-ND
D12 = 333: DIODE, SCHOTTKY, 40V VRRM, 3A IO, 60A SURGE, SOD-123; Current - Reverse Leakage @ VR: 50µA @ 40V; Database Table Name: Diodes; MFG: Panasonic Electronic Components; Voltage – Forward (VF) (MAX) @ IF: 530mV @ 3A; Category: Diode; Component Kind: Standard; ComponentLink1Description: Datasheet; ComponentLink1URL: https://drive.google.com/file/d/14E8lbKKO7Mf6PDWFiBbTpzcspmEyYvnQ/view; Current - Average Rectified (IO): 3A; Diode Type: Schottky; Height: 0.9mm; HelpURL: https://www.digikey.in/product-detail/en/panasonic-electronic-components/DB2440400L/P15191TR-ND/2804778; ID: 333; Library Name: AltiumReleaseLibDB.DBLib; Mounting Type: SMD; Operating Temperature – Junction: 125°C (Max); Package: TMiniP2-F2-B; RoHS Compliant: Yes; Status: Released; Supplier 1: Digi-Key; Supplier Part Number 1: P15191TR-ND; Voltage - DC Reverse (VR) (MAX): 40V
D15 = 334: DIODE, SCHOTTKY, 100V VRRM, 1A IO, 30A SURGE, DO-214AC; Current - Reverse Leakage @ VR: 500µA @ 100V; Database Table Name: Diodes; MFG: Diodes Incorporated; Voltage – Forward (VF) (MAX) @ IF: 790mV @ 1A; Category: Diode; Component Kind: Standard; ComponentLink1Description: Datasheet; ComponentLink1URL: https://www.diodes.com/assets/Datasheets/ds30018.pdf; Current - Average Rectified (IO): 1A; Diode Type: Schottky; Height: 2.4mm; HelpURL: https://www.digikey.in/product-detail/en/diodes-incorporated/B1100-13-F/B1100-FDICT-ND/717808; ID: 334; Library Name: AltiumReleaseLibDB.DBLib; Mounting Type: SMD; Operating Temperature – Junction: -65°C ~ 150°C; Package: SMA; RoHS Compliant: Yes; Status: Released; Supplier 1: Digi-Key; Supplier Part Number 1: B1100-FDICT-ND; Voltage - DC Reverse (VR) (MAX): 100V
FB1 FB2 = 796: FERRITE, BEAD, 220 OHM@100MHZ, 25%, 2.2A, 50 mOHM DCR, 0603; COMMON: 452-00013-00; Database Table Name: Inductors/Coils/Chokes; KOI: 185-00330-00; MFG: TDK Corporation; PROXY: 185-00247-00; Component Kind: Standard; ComponentLink1Description: Datasheet; ComponentLink1URL: http://www.tdk.co.jp/tefe02/e9413_mpz.pdf; Height: 0.95mm; ID: 796; Library Name: AltiumReleaseLibDB.DBLib; MFG P/N: MPZ1608S221A; Status: Released; XPN: 452-00013-00
J1 = 1304: CONN, MAGJACK, RJ-45, RA, 10 P, 1.27MM PITCH, 13.65MM H, GOLD, TH; Database Table Name: Connectors; MFG: EDAC Inc; Number of Positions: 20; Sub Assy Qty 2: 0; Component Kind: Standard; ComponentLink1Description: Datasheet; ComponentLink1URL: https://drive.google.com/corp/drive/folders/19zkK8jSxd_K-81Z3KWH5ztnimNFe4Kqc; Height: 13.904mm; ID: 1304; Library Name: AltiumReleaseLibDB.DBLib; Operating Temperature: 0°C ~ 70°C; RoHS Compliant: Yes; Status: Released; Sub Assy Qty 3: 0; Sub Assy Qty 4: 0
L1 = 953: IND, WIREWOUND, SEMI-SHIELDED, 6.8uH, 20%, 1A, 0.16 DCR, 1212, AUTOGRADE; Catagory: Fixed Inductors; Database Table Name: Inductors/Coils/Chokes; MFG: Bourns Inc.; Rdc max: 160mOhm; Component Kind: Standard; ComponentLink1Description: Datasheet; ComponentLink1URL: https://www.bourns.com/docs/Product-Datasheets/SRN3015TA.pdf; Height: 1.5mm; HelpURL: https://www.digikey.com/en/products/detail/bourns-inc/SRN3015TA-6R8M/6155083?s=N4IgTCBcDaIMoCUByBmADARgKwBUCCAtAGwIAcAsiALoC%2BQA; ID: 953; Idc max: 1A; Library Name: AltiumReleaseLibDB.DBLib; Status: Released; Supplier 1: Digi-Key; Supplier Part Number 1: SRN3015TA-6R8MTR-ND; Tolerance: 20%
Q1 = 181: MOSFET, PWR, N-CH, 150VDS, 4.4A ID, 56mOhm@ 4.4A, PQFN-8; Current - Continuios Drain (ID) @ 25° C: 4.4A (Ta); Database Table Name: Transistors-FET; FET Type: N-Channel; Gate Charge (QG) @ VGS: 21nC @ 10V; Input Capacitance (CISS) @ VDS: 1335pF @ 75V; MFG: ON Semiconductor; Power - Max: 2.5W (Ta), 50W (Tc); RDS On (Max) @ ID, VGS: 56mOhm @ 4.4A, 10V; VGS(TH) (Max) @ ID: 3V @ 250µA; Voltage - Drain To Source (VDSS): 150V; Category: MOS-FET; Component Kind: Standard; ComponentLink1Description: Datasheet; ComponentLink1URL: https://www.onsemi.com/pub/Collateral/FDMS86252L-D.pdf; Height: 1.1mm; HelpURL: https://www.digikey.in/product-detail/en/on-semiconductor/FDMS86252L/FDMS86252LCT-ND/4555528; ID: 181; Library Name: AltiumReleaseLibDB.DBLib; Mounting Type: SM; Operating Temperature: -55°C ~ 150°C (TJ); Package: 8-PQFN (5x6); RoHS Compliant: Yes; Status: Released; Supplier 1: Digi-Key; Supplier Part Number 1: FDMS86252LCT-ND
R1 = 4476: Resistor, 24.9K Ohms, 1206, 0.25W, ±1%; COMMON: 470-07790-00; Database Table Name: Resistors; MFG: YAGEO; MFG P/N: RC1206FR-0724K9L; Power: 1/4W; XPN: XS-10018411; Component Kind: Standard; Height: 0.65mm; ID: 4476; Library Name: AltiumReleaseLibDB.DBLib; Status: Released; Supplier 1: Digi-Key; Supplier Part Number 1: 311-24.9KFRCT-ND; Tolerance: 1%
R2 = 4002: Resistor, 6.49K Ohms, 2010, 0.75W, ±1%; COMMON: 470-07368-00; Database Table Name: Resistors; MFG: VISHAY; MFG P/N: CRCW20106K49FKEF; Power: 3/4W; XPN: XS-10018045; Component Kind: Standard; Height: 0.70mm; ID: 4002; Library Name: AltiumReleaseLibDB.DBLib; Status: Released; Supplier 1: Digi-Key; Supplier Part Number 1: 541-6.49KACCT-ND; Tolerance: 1%
R3 = 7833: RES, 3K, 1%, 1/20W, 0201; COMMON: 470-01097-00; Database Table Name: Resistors; MFG: PANASONIC; MFG P/N: ERJ1GNF3001C; Component Kind: Standard; DNP: DNP; ID: 7833; Library Name: AltiumReleaseLibDB.DBLib; Power: 1/20W; Status: Released; Tolerance: 1%; XPN: 470-01097-00
R4 = 7405: RES, 71.5K, 1%, 1/10W, 0603, AUTO GRADE; COMMON: 470-02380-00; Database Table Name: Resistors; MFG: PANASONIC; MFG P/N: ERJ3EKF7152V; XPN: XS-10013721; Component Kind: Standard; ID: 7405; Library Name: AltiumReleaseLibDB.DBLib; Power: 1/10W; Status: Released; Tolerance: 1%
R5 = 7184: RES, 24.9, 1%, 1/10W, 0603; COMMON: 470-00107-00; Database Table Name: Resistors; MFG: PANASONIC; MFG P/N: ERJ3EKF24R9V; Value: 24.9; Component Kind: Standard; ID: 7184; Library Name: AltiumReleaseLibDB.DBLib; Power: 1/10W; Status: Released; Tolerance: 1%; XPN: 470-00107-00
R6 R51 = 6940: RES, 0.0, 1/20W, 0201; COMMON: 470-00013-00; Database Table Name: Resistors; MFG: WALSIN TECHNOLOGY; MFG P/N: WR02X000PAL; PROXY: 470-10447-00; Tolerance: JUMPER; Component Kind: Standard; ID: 6940; Library Name: AltiumReleaseLibDB.DBLib; Power: 1/20W; Status: Released; XPN: 470-00013-00
  R6: DNP: DNP
R7 R10 = 8333: RES, 1K, 5%, 1/10W, 0603; COMMON: 470-02809-00; Database Table Name: Resistors; MFG: YAGEO; MFG P/N: RC0603JR-071KL; Component Kind: Standard; ID: 8333; Library Name: AltiumReleaseLibDB.DBLib; Power: 1/10W; Status: Released; Tolerance: 5%; XPN: 470-02809-00
  R7: Value: 1K
R8 = 4151: RES, 10K, 1%, 1/20W, 0201; COMMON: 470-00033-00; Database Table Name: Resistors; MFG: YAGEO; MFG P/N: RC0201FR-0710KL; PROXY: 470-16076-00; Component Kind: Standard; DNP: DNP; Height: 0.26mm; ID: 4151; Library Name: AltiumReleaseLibDB.DBLib; Power: 1/20W; Status: Released; Supplier 1: Digi-Key; Supplier Part Number 1: 311-10.0KMCT-ND; Tolerance: 1%; XPN: 470-00033-00
R9 = 805: Resistor, 270m Ohms, 1210, 1W, ±1%; COMMON: 470-04342-00; Database Table Name: Resistors; MFG: VISHAY; MFG P/N: RCWE1210R270FKEA; XPN: XS-10015284; Component Kind: Standard; Height: 0.70mm; ID: 805; Library Name: AltiumReleaseLibDB.DBLib; Power: 1W; Status: Released; Supplier 1: Digi-Key; Supplier Part Number 1: RCWE.27FCT-ND; Tolerance: 1%
R11 = 3808: Resistor, 4.02K Ohms, 0603, 0.1W, ±1%; COMMON: 470-07188-00; Database Table Name: Resistors; MFG: YAGEO; MFG P/N: RC0603FR-074K02L; Power: 1/10W; XPN: XS-10017887; Component Kind: Standard; Height: 0.55mm; ID: 3808; Library Name: AltiumReleaseLibDB.DBLib; Status: Released; Supplier 1: Digi-Key; Supplier Part Number 1: 311-4.02KHRCT-ND; Tolerance: 1%
R12 R14 = 6853: RES, 470, 5%, 1/20W, 0201; COMMON: 470-00039-00; Database Table Name: Resistors; MFG: PANASONIC; MFG P/N: ERJ1GEJ471C; Component Kind: Standard; ID: 6853; Library Name: AltiumReleaseLibDB.DBLib; Power: 1/20W; Status: NRND; Tolerance: 5%; XPN: 470-00039-00
R13 = 8491: RES, 25.5K, 1%, 0.125W, 0805, 0.6mm T; Catagory: Resistor; COMMON: 470-07797-00; Database Table Name: Resistors; MFG: Yageo; MFG P/N: RC0805FR-0725K5L; Power: 1/8W; Component Kind: Standard; ComponentLink1Description: Datasheet; ComponentLink1URL: http://www.yageo.com/documents/recent/PYu-RC_Group_51_RoHS_L_7.pdf; Height: 0.024" (0.60mm); HelpURL: https://www.digikey.com/product-detail/en/yageo/RC0805FR-0725K5L/311-25.5KCRCT-ND/730715; ID: 8491; Library Name: AltiumReleaseLibDB.DBLib; Status: Released; Supplier 1: Digi-Key; Supplier Part Number 1: 311-25.5KCRCT-ND; Tolerance: 1%
R17 = 3985: Resistor, 6.20K Ohms, 0603, 0.1W, ±1%; COMMON: 470-07351-00; Database Table Name: Resistors; MFG: YAGEO; MFG P/N: RC0603FR-076K2L; Power: 1/10W; Value: 6.2K; XPN: XS-10018030; Component Kind: Standard; Height: 0.55mm; ID: 3985; Library Name: AltiumReleaseLibDB.DBLib; Status: Released; Supplier 1: Digi-Key; Supplier Part Number 1: 311-6.20KHRCT-ND; Tolerance: 1%
R18 = 7611: RES, 2K, 1%, 1/10W, 0603; COMMON: 470-00109-00; Database Table Name: Resistors; MFG: PANASONIC; MFG P/N: ERJ3EKF2001V; Component Kind: Standard; ID: 7611; Library Name: AltiumReleaseLibDB.DBLib; Power: 1/10W; Status: Released; Tolerance: 1%; XPN: 470-00109-00
R19 = 8106: RES, 90.9K, 1%, 1/10W, 0603; COMMON: 470-00183-00; Database Table Name: Resistors; MFG: PANASONIC; MFG P/N: ERJ3EKF9092V; Component Kind: Standard; DNP: DNP; ID: 8106; Library Name: AltiumReleaseLibDB.DBLib; Power: 1/10W; Status: Released; Tolerance: 1%; XPN: 470-00183-00
R20 = 4125: Resistor, 9.10K Ohms, 0603, 0.1W, ±1%; COMMON: 470-07480-00; Database Table Name: Resistors; MFG: YAGEO; MFG P/N: RC0603FR-079K1L; Power: 1/10W; XPN: XS-10018138; Component Kind: Standard; Height: 0.55mm; ID: 4125; Library Name: AltiumReleaseLibDB.DBLib; Status: Released; Supplier 1: Digi-Key; Supplier Part Number 1: 311-9.10KHRCT-ND; Tolerance: 1%
R21 = 3693: RES, 3K, 1%, 1/10W, 0603; COMMON: 470-07085-00; Database Table Name: Resistors; MFG: YAGEO; MFG P/N: RC0603FR-073KL; PROXY: 470-16252-00; XPN: XS-10017798; Component Kind: Standard; Height: 0.55mm; ID: 3693; Library Name: AltiumReleaseLibDB.DBLib; Power: 1/10W; Status: Released; Supplier 1: Digi-Key; Supplier Part Number 1: 311-3.00KHRCT-ND; Tolerance: 1%
R22 = 7962: RES, 3.3K, 5%, 1/10W, 0603; COMMON: 470-02850-00; Database Table Name: Resistors; MFG: YAGEO; MFG P/N: RC0603JR-073K3L; Component Kind: Standard; ID: 7962; Library Name: AltiumReleaseLibDB.DBLib; Power: 1/10W; Status: Released; Tolerance: 5%; XPN: 470-02850-00
R24 = 3212: Resistor, 820 Ohms, 0603, 0.1W, ±1%; COMMON: 470-06634-00; Database Table Name: Resistors; MFG: YAGEO; MFG P/N: RC0603FR-07820RL; Power: 1/10W; XPN: XS-10017401; Component Kind: Standard; Height: 0.55mm; ID: 3212; Library Name: AltiumReleaseLibDB.DBLib; Status: Released; Supplier 1: Digi-Key; Supplier Part Number 1: 311-820HRCT-ND; Tolerance: 1%
R25 R40 = 6863: RES, 10, 5%, 1/4W, 1206; COMMON: 470-00192-00; Database Table Name: Resistors; MFG: PANASONIC; MFG P/N: ERJ8GEYJ100V; XPN: XS-10012445; Component Kind: Standard; ID: 6863; Library Name: AltiumReleaseLibDB.DBLib; Power: 1/4W; Status: Released; Tolerance: 5%
  R40: DNP: DNP
R26 = 4315: Resistor, 16.0K Ohms, 0603, 0.1W, ±1%; COMMON: 470-07648-00; Database Table Name: Resistors; MFG: STACKPOLE ELECTRONICS; MFG P/N: RMCF0603FT16K0; Power: 1/10W; XPN: XS-10018289; Component Kind: Standard; Height: 0.55mm; ID: 4315; Library Name: AltiumReleaseLibDB.DBLib; Status: Released; Supplier 1: Digi-Key; Supplier Part Number 1: RMCF0603FT16K0CT-ND; Tolerance: 1%
R27 = 4978: RES, 100K, 1%, 1/10W, 0603; COMMON: 470-02198-00; Database Table Name: Resistors; MFG: YAGEO; MFG P/N: RC0603FR-07100KL; PROXY: 470-16855-00; Component Kind: Standard; Height: 0.55mm; ID: 4978; Library Name: AltiumReleaseLibDB.DBLib; Power: 1/10W; Status: Released; Supplier 1: Digi-Key; Supplier Part Number 1: 311-100KHRCT-ND; Tolerance: 1%; XPN: 470-02198-00
R50 = 3: RES, JUMPER, 0, 1/10W, 0603; COMMON: 470-03590-00; Database Table Name: Resistors; MFG: ROHM; MFG P/N: MCR03EZPJ000; PROXY: Use ID #8521; XPN: XS-10014546; Component Kind: Standard; Height: 0.55mm; ID: 3; Library Name: AltiumReleaseLibDB.DBLib; Power: 1/10W; Status: NRND; Supplier 1: Digi-Key; Supplier Part Number 1: RHM0.0GCT-ND; Tolerance: JUMPER
T1 = 13: XFMR, PULSE, HIGH FREQUENCY, WIRE WOUND, 155uH, 30W, SMD; Catagory: Transformer; Database Table Name: Transformers; MFG: Pulse Electronics Power; Value: 155µH; Component Kind: Standard; ComponentLink1Description: Datasheet; ComponentLink1URL: https://drive.google.com/file/d/10x6F87qWxTdZZRi1enKhcAv7BcpSa0LG/view; Designator: T?; Height: 11.45mm; HelpURL: https://www.digikey.com/en/products/detail/pulse-electronics-power/PA1283NL/5174322; ID: 13; Library Name: AltiumReleaseLibDB.DBLib; Package: SMD; Status: Released; Supplier 1: Digi-Key; Supplier Part Number 1: PA1283NL-ND
U1 = 855: IC, DC-DC CONTROLLER, KTA1137A, SWITCHING, FLYBACK, 30W O/P, 9.5V TO 57V VIN, 730mA, QFN-20; Database Table Name: IC - PMIC; MFG: Kinetic Technologies; Category: Integrated Circuits (ICs); Component Kind: Standard; ComponentLink1Description: Datasheet; ComponentLink1URL: https://drive.google.com/file/d/1DZHwNSY9FBA8tHWbGrYDlAkaFDlphN9Z/view; Height: 1mm; HelpURL: https://www.mouser.com/ProductDetail/Kinetic-Technologies/KTA1137AEUAB-TR/?qs=%2Fha2pyFadugUIeoCVUpijpb10CshqG6y15RQbfE716U%3D; ID: 855; Library Name: AltiumReleaseLibDB.DBLib; Mounting Type: SMD; Package: QFN-20; RoHS Compliant: Yes; Status: Released; Supplier 1: MOUSER; Supplier Part Number 1: 389-KTA1137AEUAB-TR
U2 = 290: IC, ISOLATOR, TLP183, OPTO, TRANSISTOR, 3750V VRMS, 80V VOUT, 50mA, SOIC-6; Database Table Name: IC - Interface; MFG: Toshiba Semiconductor and Storage; Mounting Type1: Surface Mount; Category: Integrated Circuits (ICs); Component Kind: Standard; ComponentLink1Description: Datasheet; ComponentLink1URL: https://drive.google.com/file/d/1QOyWtUbi7i2iNCQDThTXWnTfKyCg0HU9/view; Height: 2.3mm; HelpURL: https://www.digikey.com/en/products/detail/toshiba-semiconductor-and-storage/TLP183-GB-TPLE/4562837?s=N4IgTCBcDaICoBkAKBGAHAZgBQHEBCAtHEggDQCiIAugL5A; ID: 290; Library Name: AltiumReleaseLibDB.DBLib; Mounting Type: SMD; Package: 6-SO, 4 Lead; RoHS Compliant: Yes; Status: Released; Supplier 1: Digi-Key; Supplier Part Number 1: TLP183(GB-TPLETR-ND
